# Altium schematic sheet PowerSupply.SchDoc(PowerSupply)
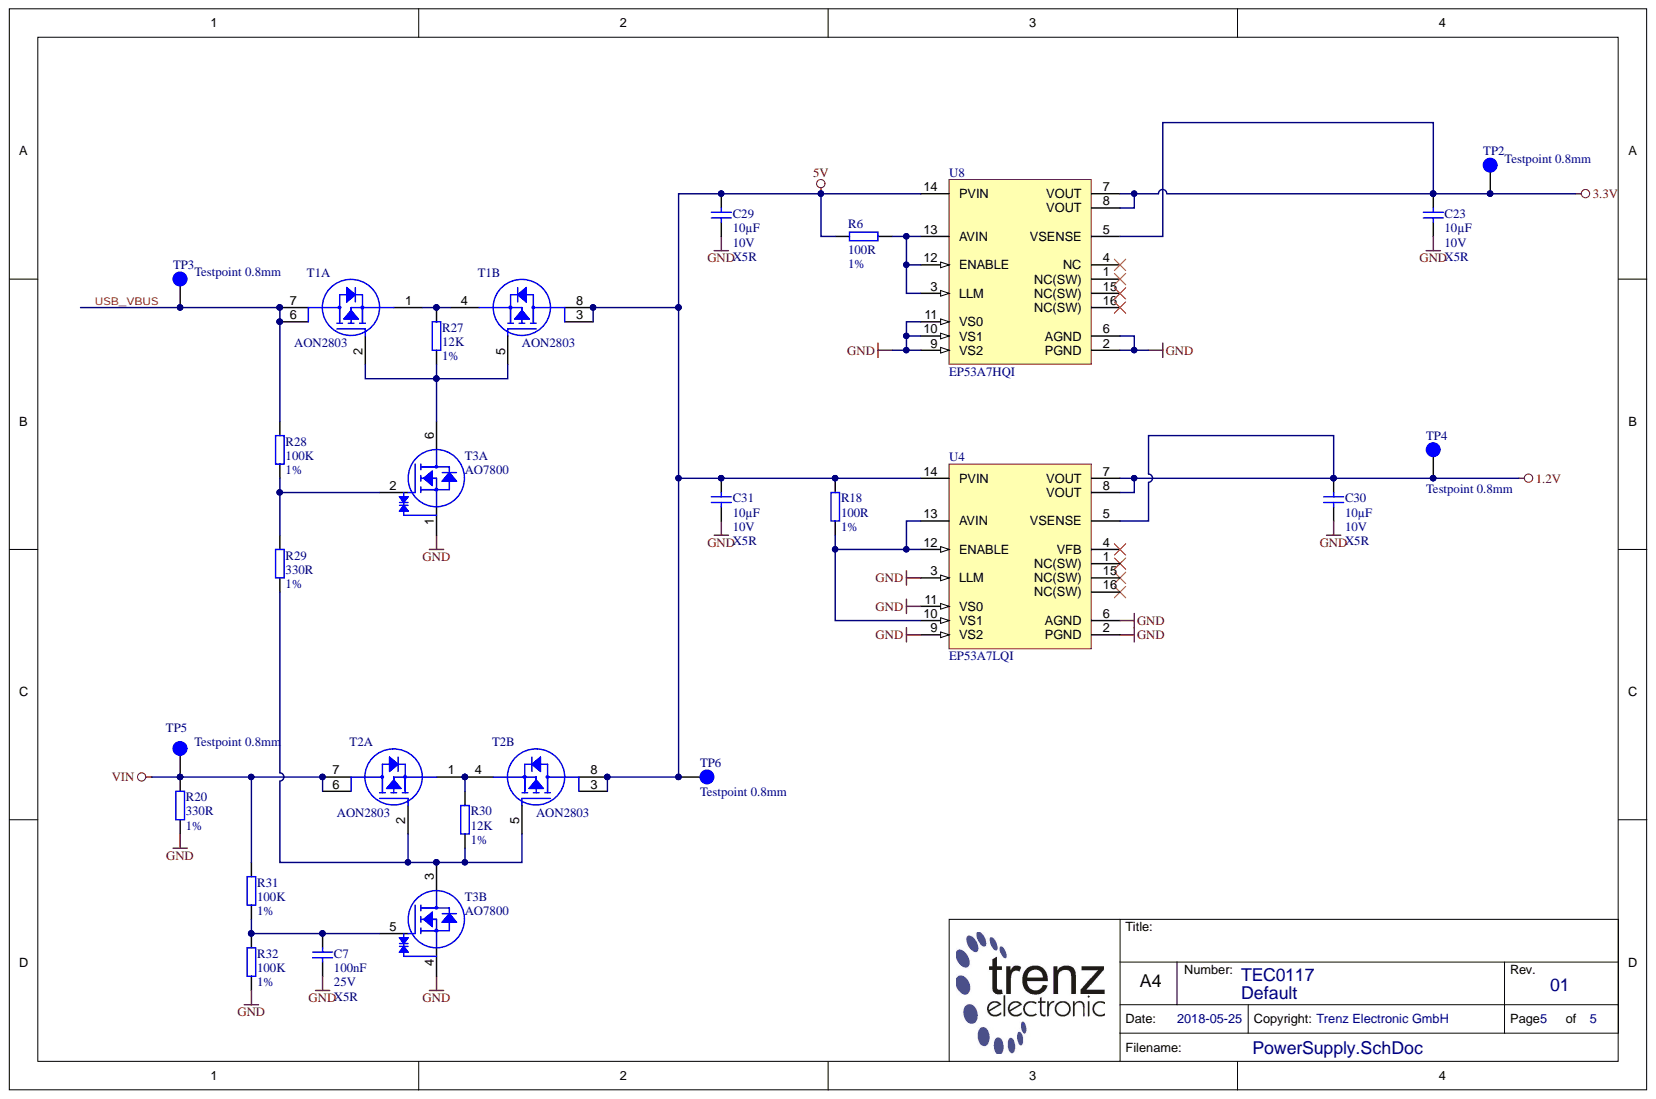
[diagram p0: PowerSupply.SchDoc]

## Parts
C7  100nF  Technology: X5R  Voltage: 25V  [CAPC1005X55N]
C23  10µF  Technology: X5R  Voltage: 10V  [CAPC2012X135N]
C29  10µF  Technology: X5R  Voltage: 10V  [CAPC2012X135N]
C30  10µF  Technology: X5R  Voltage: 10V  [CAPC2012X135N]
C31  10µF  Technology: X5R  Voltage: 10V  [CAPC2012X135N]
R6  100R  Tolerance: 1%  [RESC1005X40N]
R18  100R  Tolerance: 1%  [RESC1005X40N]
R20  330R  Tolerance: 1%  [RESC1005X40N]
R27  12K  Tolerance: 1%  [RESC1005X40N]
R28  100K  Tolerance: 1%  [RESC1005X40N]
R29  330R  Tolerance: 1%  [RESC1005X40N]
R30  12K  Tolerance: 1%  [RESC1005X40N]
R31  100K  Tolerance: 1%  [RESC1005X40N]
R32  100K  Tolerance: 1%  [RESC1005X40N]
T1  AON2803  [SON65P200X200X80-8T10X06]  (parts A,B)
T2  AON2803  [SON65P200X200X80-8T10X06]  (parts A,B)
T3  AO7800  [SOT65P210X110-6N]  (parts A,B)
TP2  Testpoint 0.8mm  [TP_0.8mm]
TP3  Testpoint 0.8mm  [TP_0.8mm]
TP4  Testpoint 0.8mm  [TP_0.8mm]
TP5  Testpoint 0.8mm  [TP_0.8mm]
TP6  Testpoint 0.8mm  [TP_0.8mm]
U4  EP53A7LQI  [EP53F8QI]
U8  EP53A7HQI  [EP53F8QI]

## Nets
1.2V: C30.1 TP4.1 U4.5(VSENSE) U4.7(VOUT) U4.8(VOUT)
3.3V: C23.1 TP2.1 U8.5(VSENSE) U8.7(VOUT) U8.8(VOUT)
5V: C29.1 C31.1 R6.1 R18.2 T1.3 T1.8 T2.3 T2.8 TP6.1 U4.14(PVIN) U8.14(PVIN)
GND: C7.1 C23.2 C29.2 C30.2 C31.2 R20.1 R32.2 T3.1 T3.4 U4.2(PGND) U4.3(LLM) U4.6(AGND) U4.9(VS2) U4.11(VS0) U8.2(PGND) U8.6(AGND) U8.9(VS2) U8.10(VS1) U8.11(VS0)
VIN: R20.2 R31.1 T2.6 T2.7 TP5.1
USB_VBUS: R28.1 T1.6 T1.7 TP3.1
NetC7_2: C7.2 R31.2 R32.1 T3.5
NetR6_2: R6.2 U8.3(LLM) U8.12(ENABLE) U8.13(AVIN)
NetR18_1: R18.1 U4.10(VS1) U4.12(ENABLE) U4.13(AVIN)
NetR27_1: R27.1 T1.2 T1.5 T3.6
NetR27_2: R27.2 T1.1 T1.4
NetR28_2: R28.2 R29.2 T3.2
NetR29_1: R29.1 R30.1 T2.2 T2.5 T3.3
NetR30_2: R30.2 T2.1 T2.4

Not connected: U4 1(NC(SW)) 4(VFB) 15(NC(SW)) 16(NC(SW)); U8 1(NC(SW)) 4(NC) 15(NC(SW)) 16(NC(SW))

## Library data of the parts
C7 = 22472: KON 100nF 25V X5R ±10% SMD BF 1005 (0402); Database Table Name: C_1005_0402; Mixed: SMT; Preferred: Yes; Temperature-Range: -55/+85; Value: 100n; AN: 22472; Case: 1005 (0402); Component Kind: Standard; Library Name: TE.DbLib
C23 C29-C31 = 28014: KON 10µF 10V X5R 10% SMD BF 2012 (0805); Database Table Name: C_2012_0805; Mixed: SMT; Temperature-Range: -55/+85; Value: 10u; AN: 28014; Case: 2012 (0805); Component Kind: Standard; Library Name: TE.DbLib; Tolerance: 10%
R6 R18 = 22507: WID SMD 100R 1% 0,063W  BF 1005 (0402); Database Table Name: R_1005_0402; H-ART: RC0402FR-13100RL; Mixed: SMT; Preferred: Yes; Value: 100; AN: 22507; Case: 1005 (0402); Component Kind: Standard; Library Name: TE.DbLib; Technology: TK100
R20 R29 = 22762: WID SMD 330R 1% 0,063W  BF 1005 (0402); Database Table Name: R_1005_0402; H-ART: RC0402FR-13330RL; Mixed: SMT; Preferred: Yes; Value: 330; AN: 22762; Case: 1005 (0402); Component Kind: Standard; Library Name: TE.DbLib; Technology: TK100
R27 R30 = 22598: WID SMD 12K 1% 0,063W  BF 1005 (0402); Database Table Name: R_1005_0402; Mixed: SMT; Preferred: Yes; AN: 22598; Case: 1005 (0402); Component Kind: Standard; Library Name: TE.DbLib; Technology: TK100; Value: 12K
R28 R31 R32 = 22558: WID SMD 100K 1% 0,063W  BF 1005 (0402); Database Table Name: R_1005_0402; H-ART: RC0402FR-13100KL; Mixed: SMT; Preferred: Yes; AN: 22558; Case: 1005 (0402); Component Kind: Standard; Library Name: TE.DbLib; Technology: TK100; Value: 100K
T1 T2 = 28041: Database Table Name: TRANSISTOR; Mixed: SMT; Temperature-Range: -55/+150 (Tj abs); Value: -3.8A; Voltage: -20V; AN: 28041; Case: DFN6; Component Kind: Standard; Description: AON2803; H-ART: AON2803; Library Name: TE.DbLib; HelpURL: b:\AD\adlib\datasheet\AON2803\
T3 = 28043: Database Table Name: TRANSISTOR; Mixed: SMT; Temperature-Range: -55/+150 (Tj abs); Value: 0.9A; Voltage: 20V; AN: 28043; Case: SOT23-6; Component Kind: Standard; Description: AO7800; H-ART: AO7800; Library Name: TE.DbLib; HelpURL: b:\AD\adlib\datasheet\AO7800\
TP2-TP6 = 26289: Database Table Name: PCB; Mixed: MECH; AN: 26289; Component Kind: Standard; Description: Testpoint 0.8mm; Library Name: TE.DbLib
U4 = 28280: Database Table Name: DCDC; Mixed: SMT; Preferred: Yes; Temperature-Range: -40/+85; AN: 28280; Case: QFN16; Component Kind: Standard; Description: EP53A7LQI; H-ART: EP53A7LQI; Library Name: TE.DbLib; Trenz-Stock: Yes; HelpURL: B:\AD\adlib\datasheet\EP53A7HQI
U8 = 27996: Database Table Name: DCDC; Mixed: SMT; Preferred: Yes; Temperature-Range: -40/+85; AN: 27996; Case: QFN16; Component Kind: Standard; Description: EP53A7HQI; H-ART: EP53A7HQI; Library Name: TE.DbLib; Trenz-Stock: Yes; HelpURL: B:\AD\adlib\datasheet\EP53A7HQI
